# Altium schematic sheet SCH_BSPD_Main.SchDoc
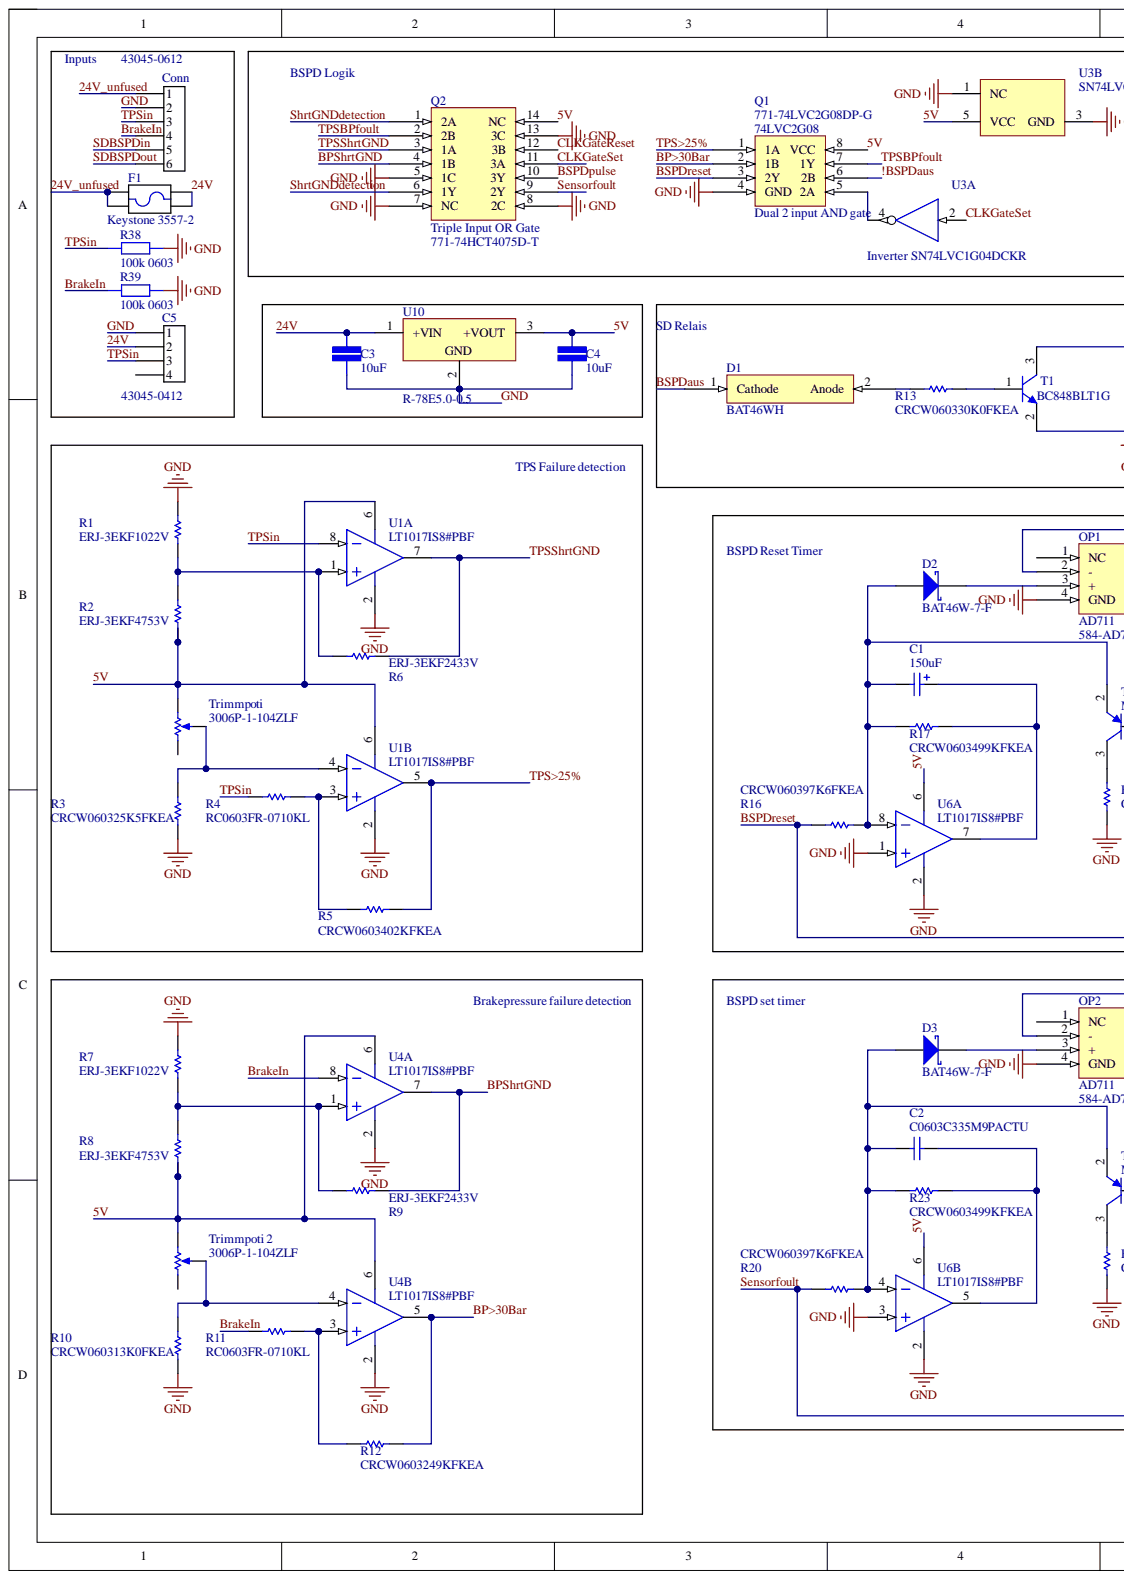
[diagram p0: SCH_BSPD_Main.SchDoc, left]
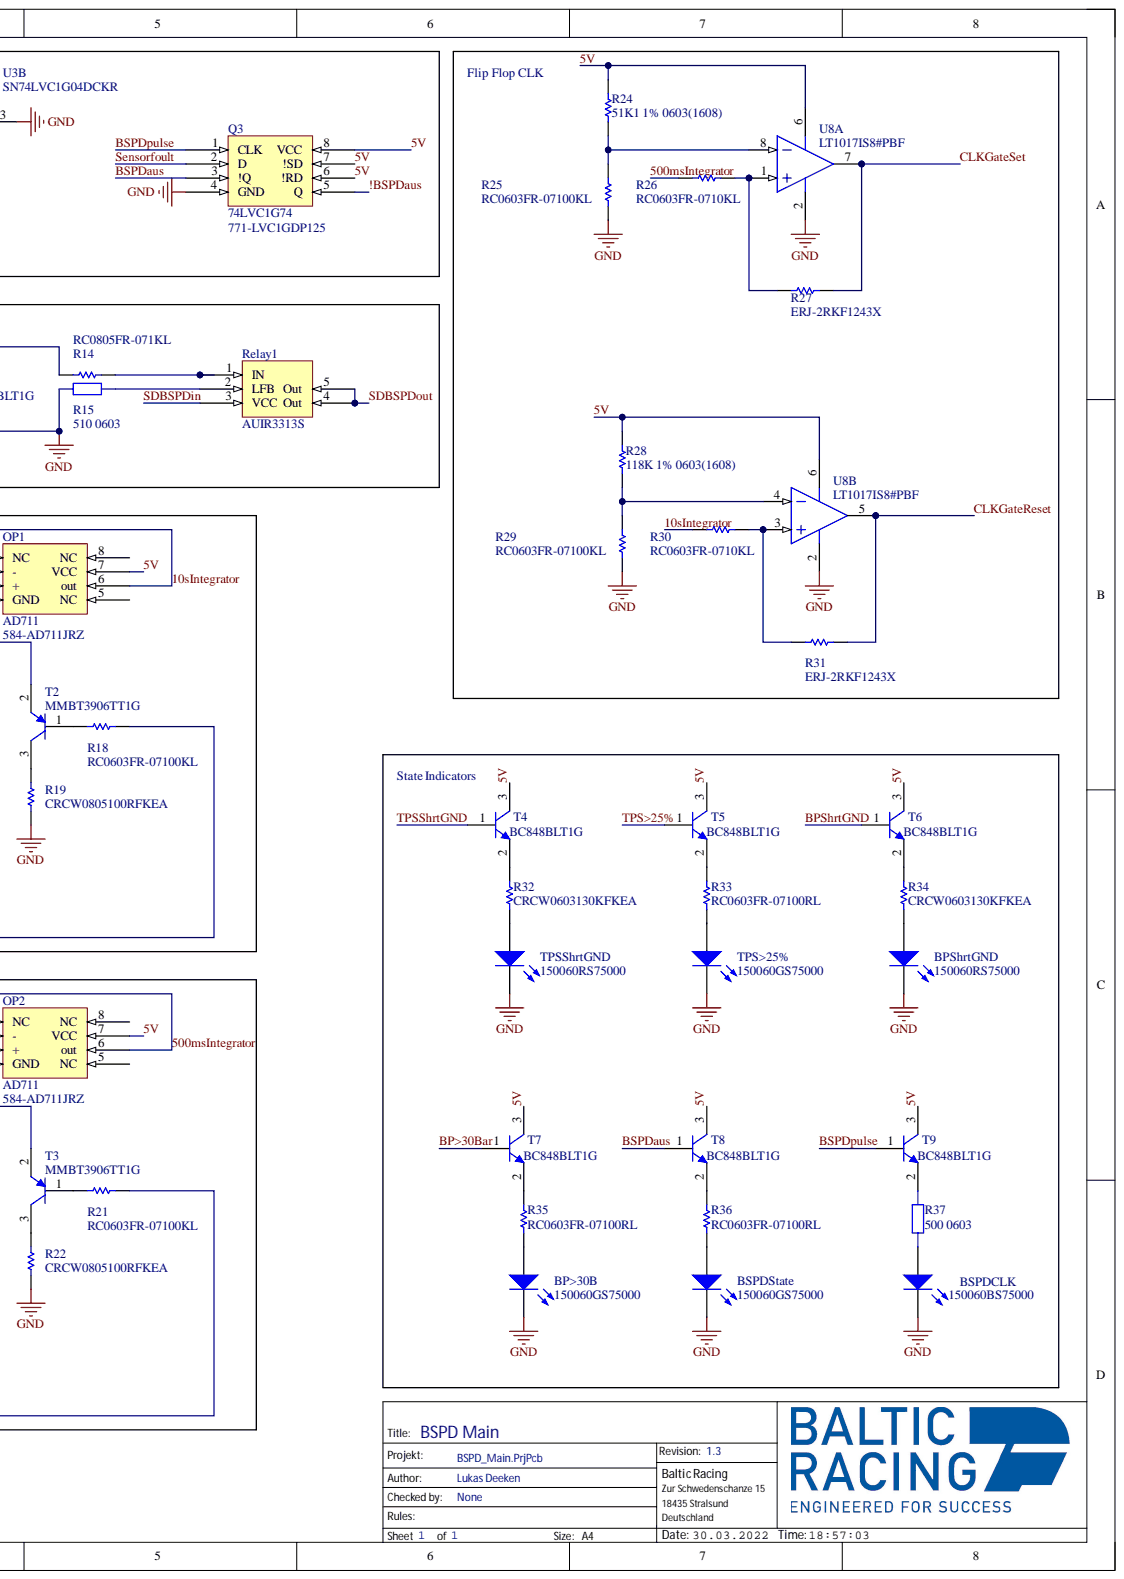
[diagram p1: SCH_BSPD_Main.SchDoc, right]

## Parts
BP>30B  150060GS75000  [0603_A]
BPShrtGND  150060RS75000  [0603_A]
BSPDCLK  150060BS75000  [0603_A]
BSPDState  150060GS75000  [0603_A]
C1  value 150uF  [WCAP-PSLC_10x12.4]  (hidden comment: 875075561006)
C2  C0603C335M9PACTU  [CAPC1608X90X35NL15T15]
C3  value 10uF  [CAP 0805/2012]  (hidden comment: SMD Capacitor)
C4  value 10uF  [CAP 0805/2012]  (hidden comment: SMD Capacitor)
C5  43045-0412  [43045-0412]
Conn  43045-0612  [43045-0612]
D1  BAT46WH  [DIOM2715X18N]
D2  BAT46W-7-F  [DIOD-SOD-123-2_V]
D3  BAT46W-7-F  [DIOD-SOD-123-2_V]
F1  Keystone 3557-2  [Keystone 3557-2]
OP1  AD711  Supplier Part Number 1: 584-AD711JRZ  [SOIC8; SOIC127P600X175-8N]
OP2  AD711  Supplier Part Number 1: 584-AD711JRZ  [SOIC8; SOIC127P600X175-8N]
Q1  Dual 2 input AND gate  Supplier Part Number 1: 771-74LVC2G08DP-G  [TSSOP8; TSOP65P400X110-8N]
Q2  Triple Input OR Gate  Supplier Part Number 1: 771-74HCT4075D-T  [SOIC14; SOIC127P600X175-14N]
Q3  74LVC1G74  Supplier Part Number 1: 771-LVC1GDP125  [TSSOP8; TSOP65P400X110-8N]
R1  ERJ-3EKF1022V  [RESC1608X55X30LL15T15]
R2  ERJ-3EKF4753V  [RESC1608X55X30LL15T15]
R3  CRCW060325K5FKEA  [RESC1609X50X30ML10T20]
R4  RC0603FR-0710KL  [RESC1608X55X25ML10T15]
R5  CRCW0603402KFKEA  [RESC1609X50X30ML10T20]
R6  ERJ-3EKF2433V  [RESC1608X55X30ML15T15]
R7  ERJ-3EKF1022V  [RESC1608X55X30LL15T15]
R8  ERJ-3EKF4753V  [RESC1608X55X30LL15T15]
R9  ERJ-3EKF2433V  [RESC1608X55X30ML15T15]
R10  CRCW060313K0FKEA  [RESC1609X50X30NL10T20]
R11  RC0603FR-0710KL  [RESC1608X55X25ML10T15]
R12  CRCW0603249KFKEA  [RESC1609X50X30NL10T20]
R13  CRCW060330K0FKEA  [RESC1609X50X30LL10T20]
R14  RC0805FR-071KL  [RESC2013X60X35ML10T20]
R15  value 510 0603  [STACKPOLE CSR0603]  (hidden comment: SMD Resistor)
R16  CRCW060397K6FKEA  [RESC1609X50X30LL10T20]
R17  CRCW0603499KFKEA  [RESC1609X50X30NL10T20]
R18  RC0603FR-07100KL  [RESC1608X55X25ML10T15]
R19  CRCW0805100RFKEA  [RESC2013X50X30LL20T20]
R20  CRCW060397K6FKEA  [RESC1609X50X30LL10T20]
R21  RC0603FR-07100KL  [RESC1608X55X25ML10T15]
R22  CRCW0805100RFKEA  [RESC2013X50X30LL20T20]
R23  CRCW0603499KFKEA  [RESC1609X50X30NL10T20]
R24  51K1 1% 0603(1608)  [RESC0603(1608)_L]
R25  RC0603FR-07100KL  [RESC1608X55X25ML10T15]
R26  RC0603FR-0710KL  [RESC1608X55X25ML10T15]
R27  ERJ-2RKF1243X  [RESC1005X40X25NL05T05]
R28  118K 1% 0603(1608)  [RESC0603(1608)_M]
R29  RC0603FR-07100KL  [RESC1608X55X25ML10T15]
R30  RC0603FR-0710KL  [RESC1608X55X25ML10T15]
R31  ERJ-2RKF1243X  [RESC1005X40X25NL05T05]
R32  CRCW0603130KFKEA  [RESC1609X50X30NL10T20]
R33  RC0603FR-07100RL  [RESC1608X55X25ML10T15]
R34  CRCW0603130KFKEA  [RESC1609X50X30NL10T20]
R35  RC0603FR-07100RL  [RESC1608X55X25ML10T15]
R36  RC0603FR-07100RL  [RESC1608X55X25ML10T15]
R37  value 500 0603  [STACKPOLE CSR0603]  (hidden comment: SMD Resistor)
R38  value 100k 0603  [STACKPOLE CSR0603]  (hidden comment: SMD Resistor)
R39  value 100k 0603  [STACKPOLE CSR0603]  (hidden comment: SMD Resistor)
Relay1  AUIR3313S  [D2PAK5 ;DPAK228P1524X483-5N]
T1  BC848BLT1G  [ONSC-SOT-23-3-318-08_V]
T2  MMBT3906TT1G  [ONSC-SC-75-3-463-01_V]
T3  MMBT3906TT1G  [ONSC-SC-75-3-463-01_V]
T4  BC848BLT1G  [ONSC-SOT-23-3-318-08_V]
T5  BC848BLT1G  [ONSC-SOT-23-3-318-08_V]
T6  BC848BLT1G  [ONSC-SOT-23-3-318-08_V]
T7  BC848BLT1G  [ONSC-SOT-23-3-318-08_V]
T8  BC848BLT1G  [ONSC-SOT-23-3-318-08_V]
T9  BC848BLT1G  [ONSC-SOT-23-3-318-08_V]
TPS>25%  150060GS75000  [0603_A]
TPSShrtGND  150060RS75000  [0603_A]
Trimmpoti  3006P-1-104ZLF  [BOUR-3006P-3_V]
Trimmpoti_2  3006P-1-104ZLF  [BOUR-3006P-3_V]
U1  LT1017IS8#PBF  [LT-S8_N]  (parts A,B)
U3  Inverter SN74LVC1G04DCKR  [DCK0005A_N]  (parts A,B)
U4  LT1017IS8#PBF  [LT-S8_N]  (parts A,B)
U6  LT1017IS8#PBF  [LT-S8_N]  (parts A,B)
U8  LT1017IS8#PBF  [LT-S8_N]  (parts A,B)
U10  R-78E5.0-0.5  [REPO-R-78E-0.5-3_V]

## Nets
5V: C4.2 OP1.7(VCC) OP2.7(VCC) Q1.8(VCC) Q2.14(NC) Q3.6(!RD) Q3.7(!SD) Q3.8(VCC) R2.1 R8.1 R24.2 R28.2 T4.3 T5.3 T6.3 T7.3 T8.3 T9.3 Trimmpoti.1 Trimmpoti_2.1 U1.6 U3.5(VCC) U4.6 U6.6 U8.6 U10.3
10sIntegrator: OP1.2(-) OP1.6(out) R30.1
24V: C3.2 C5.2 F1.O1 F1.O2 U10.1
24V_unfused: Conn.1 F1.I1 F1.I2
500msIntegrator: OP2.2(-) OP2.6(out) R26.1
!BSPDaus: Q1.6(2B) Q3.5(Q)
BP>30Bar: Q1.2(1B) R12.2 T7.1 U4.5
BPShrtGND: Q2.4(1B) R9.2 T6.1 U4.7
BrakeIn: Conn.4 R11.1 R39.1 U4.8
BSPDaus: D1.1 Q3.3(!Q) T8.1
BSPDpulse: Q2.10(3Y) Q3.1(CLK) T9.1
BSPDreset: Q1.3(2Y) R16.1 R18.1
CLKGateReset: Q2.12(3B) R31.2 U8.5
CLKGateSet: Q2.11(3A) R27.2 U3.2 U8.7
GND: BP>30B.1 BPShrtGND.1 BSPDCLK.1 BSPDState.1 C3.1 C4.1 C5.1 Conn.2 OP1.4(GND) OP2.4(GND) Q1.4(GND) Q2.5(1C) Q2.7(NC) Q2.8(2C) Q2.13(3C) Q3.4(GND) R1.2 R3.1 R7.2 R10.1 R15.1 R19.2 R22.2 R25.1 R29.1 R38.2 R39.2 T1.2 TPS>25%.1 TPSShrtGND.1 U1.2 U3.1(NC) U3.3(GND) U4.2 U6.1 U6.2 U6.3 U8.2 U10.2
SDBSPDin: Conn.5 Relay1.3(VCC)
SDBSPDout: Conn.6 Relay1.4(Out) Relay1.5(Out)
Sensorfoult: Q2.9(2Y) Q3.2(D) R20.1 R21.1
ShrtGNDdetection: Q2.1(2A) Q2.6(1Y)
TPS>25%: Q1.1(1A) R5.2 T5.1 U1.5
TPSBPfoult: Q1.7(1Y) Q2.2(2B)
TPSin: C5.3 Conn.3 R4.1 R38.1 U1.8
TPSShrtGND: Q2.3(1A) R6.2 T4.1 U1.7
NetBP>30B_2: BP>30B.2 R35.2
NetBPShrtGND_2: BPShrtGND.2 R34.1
NetBSPDCLK_2: BSPDCLK.2 R37.1
NetBSPDState_2: BSPDState.2 R36.2
NetC1_1: C1.1 R17.2 U6.7
NetC1_2: C1.2 D2.1 R16.2 R17.1 T2.2 U6.8
NetC2_1: C2.1 D3.1 R20.2 R23.1 T3.2 U6.4
NetC2_2: C2.2 R23.2 U6.5
NetD1_2: D1.2 R13.1
NetD2_2: D2.2 OP1.3(+)
NetD3_2: D3.2 OP2.3(+)
NetQ1_5: Q1.5(2A) U3.4
NetR1_1: R1.1 R2.2 R6.1 U1.1
NetR3_2: R3.2 Trimmpoti.2 U1.4
NetR4_2: R4.2 R5.1 U1.3
NetR7_1: R7.1 R8.2 R9.1 U4.1
NetR10_2: R10.2 Trimmpoti_2.2 U4.4
NetR11_2: R11.2 R12.1 U4.3
NetR13_2: R13.2 T1.1
NetR14_1: R14.1 T1.3
NetR14_2: R14.2 Relay1.1(IN)
NetR15_2: R15.2 Relay1.2(LFB)
NetR18_2: R18.2 T2.1
NetR19_1: R19.1 T2.3
NetR21_2: R21.2 T3.1
NetR22_1: R22.1 T3.3
NetR24_1: R24.1 R25.2 U8.8
NetR26_2: R26.2 R27.1 U8.1
NetR28_1: R28.1 R29.2 U8.4
NetR30_2: R30.2 R31.1 U8.3
NetR32_1: R32.1 TPSShrtGND.2
NetR32_2: R32.2 T4.2
NetR33_1: R33.1 T5.2
NetR33_2: R33.2 TPS>25%.2
NetR34_2: R34.2 T6.2
NetR35_1: R35.1 T7.2
NetR36_1: R36.1 T8.2
NetR37_2: R37.2 T9.2

Not connected: C5 4; OP1 1(NC) 5(NC) 8(NC); OP2 1(NC) 5(NC) 8(NC); Trimmpoti 3; Trimmpoti_2 3

## Library data of the parts
BP>30B BSPDState TPS>25% = CMP-1426-00002-1: SMD mono-color Chip LED, WL-SMCW, Green; Manufacturer: Wuerth Elektronik; Color: Green; Component Kind: Standard; ComponentLink1Description: Manufacturer URL; ComponentLink1URL: http://www.we-online.com; ComponentLink2Description: Datasheet; ComponentLink2URL: http://katalog.we-online.com/led/datasheet/150060GS75000.pdf; Library Name: Altium Content Vault; PackageReference: 0603_A; PartNumber: 150060GS75000
BPShrtGND TPSShrtGND = CMP-1426-00001-1: SMD mono-color Chip LED, WL-SMCW, Red; Manufacturer: Wuerth Elektronik; Color: Red; Component Kind: Standard; ComponentLink1Description: Manufacturer URL; ComponentLink1URL: http://www.we-online.com; ComponentLink2Description: Datasheet; ComponentLink2URL: http://katalog.we-online.com/led/datasheet/150060RS75000.pdf; Library Name: Altium Content Vault; PackageReference: 0603_A; PartNumber: 150060RS75000
BSPDCLK = CMP-1426-00003-1: SMD mono-color Chip LED, WL-SMCW, Blue; Manufacturer: Wuerth Elektronik; Color: Blue; Component Kind: Standard; ComponentLink1Description: Manufacturer URL; ComponentLink1URL: http://www.we-online.com; ComponentLink2Description: Datasheet; ComponentLink2URL: http://katalog.we-online.com/led/datasheet/150060BS75000.pdf; Library Name: Altium Content Vault; PackageReference: 0603_A; PartNumber: 150060BS75000
C1 = 875075561006: WCAP-PSLC Aluminum Polymer Capacitors, size Ф10x12.4mm, 25V DC, 150uF; Rated Voltage: 25V DC; Component Kind: Standard; ComponentLink1Description: Manufacturer; ComponentLink1URL: http://www.we-online.de; ComponentLink2Description: Datasheet; ComponentLink2URL: http://katalog.we-online.de/pbs/datasheet/875075561006.pdf; Library Name: ALTIUM-WCAP-PSLC-rev17b.IntLib; Life Cycle: 2000h; Operating Temperature: -55 °C up to +105 °C; Part Number: 875075561006; Published Date: June 2017; Size: Ф10x12.4mm; Publisher: Wurth Elektronik
C2 = CMP-2006-02261-1: Manufacturer: Kemet; Component Kind: Standard; Library Name: Altium Content Vault; Part Number: C0603C335M9PACTU
C3 = SMD Capacitor: Capacitor with multiple Footprints; Voltage: 25V; Component Kind: Standard; Library Name: Standardbauteile.IntLib
C4 = SMD Capacitor: Capacitor with multiple Footprints; Voltage: 6.3V; Component Kind: Standard; Library Name: Standardbauteile.IntLib
C5 = MicroFit3.0 4-Pin: Molex Micro-Fit 3.0 4-Pin  600V  8.5A; Component Kind: Standard; Library Name: Standardbauteile.IntLib
Conn = MicroFit3.0 6-Pin: Molex Micro-Fit 3.0 6-Pin  600V  8.5A; Component Kind: Standard; Library Name: Standardbauteile.IntLib
D2 D3 = CMP-0303-00004-1: Surface Mount Schottky Barrier Diode, 100 V, -55 to 125 degC, 2-Pin SOD123, RoHS, Tape and Reel; Manufacturer: Diodes Inc; CT@1 V: 12 pF Typ.; IR@1.5 V: 0.3 uA Max.; V(BR)R: 100 V Min.; VF@10 mA: 0.45 V Max.; Component Kind: Standard; ComponentLink1Description: Manufacturer URL; ComponentLink1URL: http://www.diodes.com/; ComponentLink2Description: Datasheet; ComponentLink2URL: http://diodes.com/datasheets/ds30044.pdf; ComponentLink3Description: Package Specification; ComponentLink3URL: http://diodes.com/datasheets/ds30044.pdf; DatasheetVersion: Rev. 16-2; Library Name: Altium Content Vault; Mounting Technology: Surface Mount; Operating Temperature: -55 to 125 degC; PackageDescription: 2-Pin SMD, Body 2.7 x 1.55 mm, Height 1.45 mm; Packing: Tape and Reel; PartNumber: BAT46W-7-F; RoHS: TRUE
F1 = Keystone 3557-2: Keystone 3557-2  Fuse Holder; Component Kind: Standard; ComponentLink1Description: Octopart; ComponentLink1URL: https://octopart.com/3557-2-keystone-850400?r=sp; Library Name: libNico.IntLib
Q1 = 74LVC2G08-Q100: Component Kind: Standard; Supplier 1: Mouser; Library Name: Integrated_LibraryLukas.IntLib
Q2 = 74HCT4075D,653: Component Kind: Standard; Supplier 1: Mouser; Library Name: Integrated_LibraryLukas.IntLib
R1 R7 = CMP-2000-00185-1: Manufacturer: Panasonic; Component Kind: Standard; Library Name: Altium Content Vault; Part Number: ERJ-3EKF1022V
R2 R8 = CMP-2000-00528-1: Manufacturer: Panasonic; Component Kind: Standard; Library Name: Altium Content Vault; Part Number: ERJ-3EKF4753V
R3 = CMP-2000-03129-1: Manufacturer: Vishay Dale; Component Kind: Standard; Library Name: Altium Content Vault; Part Number: CRCW060325K5FKEA
R4 R11 R26 R30 = CMP-2100-03664-1: Chip Resistor, 10 KOhm, +/- 1%, 01 W, -55 to 155 degC, 0603 (1608 Metric), RoHS, Tape and Reel; Manufacturer: Yageo; Power Rating: 100mW; Resistance: 10KOhm; Temperature Coefficient: 100ppm/degreesC; Voltage Rating: 75V; Voltage Rating (DC): 50V; Case Code (Imperial): 0603; Case Code (Metric): 1608; Case/Package: 0603; Component Kind: Standard; Composition: ThickFilm; Datasheet URL: http://www.yageo.com/NewPortal/yageodocoutput?fileName=/pdf/R-Chip/PYu-RC_Group_51_RoHS_L_5.pdf; Datasheet Version: Rev. 5, 10/2016; Depth: 0.8mm; Height: 0.45mm; Lead Free: LeadFree; Lead Pitch: 0.3mm; Length: 1.6mm; Library Name: Altium Content Vault; Manufacturer URL: http://www.yageo.com/; Max Operating Temperature: 155degC; Military Standard: Not; Min Operating Temperature: -55degC; Number of Pins: 2; Package Description: 2-Pin Surface Mount Device, Body 1.6 x 0.8 mm; Package Reference: 0603; Packaging: TapeandReel; REACH SVHC: NoSVHC; RoHSCompliant: true; Termination: SMD/SMT; Tolerance: 1%; Weight: 7.1E-05oz; Width: 0.8mm
R5 = CMP-2000-03334-1: Manufacturer: Vishay Dale; Component Kind: Standard; Library Name: Altium Content Vault; Part Number: CRCW0603402KFKEA
R6 R9 = CMP-2000-00367-1: Manufacturer: Panasonic; Component Kind: Standard; Library Name: Altium Content Vault; Part Number: ERJ-3EKF2433V
R10 = CMP-2000-03895-1: Manufacturer: Vishay Dale; Component Kind: Standard; Library Name: Altium Content Vault; Part Number: CRCW060313K0FKEA
R12 = CMP-2000-03115-1: Manufacturer: Vishay Dale; Component Kind: Standard; Library Name: Altium Content Vault; Part Number: CRCW0603249KFKEA
R13 = CMP-2000-03223-1: Manufacturer: Vishay Dale; Component Kind: Standard; Library Name: Altium Content Vault; Part Number: CRCW060330K0FKEA
R14 = CMP-2100-03671-1: Chip Resistor, 1 KOhm, +/- 1%, 125 mW, -55 to 155 degC, 0805 (2012 Metric), RoHS, Tape and Reel; Manufacturer: Yageo; Max Power Dissipation: 125mW; Resistance: 1KOhm; Temperature Coefficient: 100ppm/degreesC; Voltage Rating: 150V; Case Code (Imperial): 0805; Case Code (Metric): 2012; Case/Package: 0805; Component Kind: Standard; Composition: ThickFilm; Datasheet URL: http://www.yageo.com/NewPortal/yageodocoutput?fileName=/pdf/R-Chip/PYu-RC_Group_51_RoHS_L_5.pdf; Datasheet Version: Rev. 5, 10/2016; Depth: 1.25mm; Height: 0.024inch; Lead Free: LeadFree; Length: 0.079inch; Library Name: Altium Content Vault; Manufacturer URL: http://www.yageo.com/; Max Operating Temperature: 155degC; Military Standard: Not; Min Operating Temperature: -55degC; Number of Pins: 2; Number of Terminations: 2; Package Description: 2-Pin Surface Mounted Device, Body 2 x 1.25 mm; Package Quantity: 1; Package Reference: 0805; Packaging: TapeandReel; Power Rating: 125mW; REACH SVHC: Unknown; RoHSCompliant: true; Termination: SMD/SMT; Tolerance: 1%; Voltage Rating (DC): 150V; Width: 0.049inch
R15 R37-R39 = SMD Resistor: Resistor with multiple footprints; Component Kind: Standard; Library Name: Standardbauteile.IntLib
R16 R20 = CMP-2000-03650-1: Manufacturer: Vishay Dale; Component Kind: Standard; Library Name: Altium Content Vault; Part Number: CRCW060397K6FKEA
R17 R23 = CMP-2000-03389-1: Manufacturer: Vishay Dale; Component Kind: Standard; Library Name: Altium Content Vault; Part Number: CRCW0603499KFKEA
R18 R21 R25 R29 = CMP-2100-03662-1: Chip Resistor, 100 KOhm, +/- 1%, 0.1 W, -55 to 155 degC, 0603 (1608 Metric), RoHS, Tape and Reel; Manufacturer: Yageo; Power Rating: 100mW; Temperature Coefficient: 100ppm/degreesC; Voltage Rating: 50V; Case Code (Imperial): 0603; Case Code (Metric): 1608; Case/Package: 0603; Component Kind: Standard; Composition: ThickFilm; Datasheet URL: http://www.yageo.com/NewPortal/yageodocoutput?fileName=/pdf/R-Chip/PYu-RC_Group_51_RoHS_L_5.pdf; Datasheet Version: Rev. 5, 10/2016; Depth: 0.8mm; Height: 0.45mm; Lead Free: LeadFree; Length: 1.6mm; Library Name: Altium Content Vault; Manufacturer URL: http://www.yageo.com/; Max Operating Temperature: 155degC; Military Standard: Not; Min Operating Temperature: -55degC; Number of Pins: 2; Package Description: 2-Pin Surface Mount Device, Body 1.6 x 0.8 mm; Package Reference: 0603; Packaging: TapeandReel; REACH SVHC: NoSVHC; Resistance: 100KOhm; RoHSCompliant: true; Termination: SMD/SMT; Tolerance: 1%; Voltage Rating (DC): 50V; Width: 0.8mm
R19 R22 = CMP-2001-04901-1: Manufacturer: Vishay Dale; Component Kind: Standard; Library Name: Altium Content Vault; Part Number: CRCW0805100RFKEA
R24 = CMP-1012-00704-1: 51K1 0.1W 1% 0603 (1608 Metric)  SMD; Case-EIA: 0603; Case-Metric: 1608; Value: 51100.00 Ohm; Component Kind: Standard; Library Name: Altium Content Vault; Power: 0.1 W; Technology: SMT; Tolerance: 1 %
R27 R31 = CMP-2002-00654-1: Manufacturer: Panasonic; Component Kind: Standard; Library Name: Altium Content Vault; Part Number: ERJ-2RKF1243X
R28 = CMP-1012-00743-1: 118K 0.1W 1% 0603 (1608 Metric)  SMD; Case-EIA: 0603; Case-Metric: 1608; Value: 118000.00 Ohm; Component Kind: Standard; Library Name: Altium Content Vault; Power: 0.1 W; Technology: SMT; Tolerance: 1 %
R32 R34 = CMP-2000-03887-1: Manufacturer: Vishay Dale; Component Kind: Standard; Library Name: Altium Content Vault; Part Number: CRCW0603130KFKEA
R33 R35 R36 = CMP-2100-03663-1: Chip Resistor, 100 Ohm, +/- 1%, 0.1 W, -55 to 155 degC, 0603 (1608 Metric), RoHS, Tape and Reel; Manufacturer: Yageo; Power Rating: 100mW; Resistance: 100Ohm; Temperature Coefficient: 100ppm/degreesC; Voltage Rating: 50V; Case Code (Imperial): 0603; Case Code (Metric): 1608; Case/Package: 0603; Component Kind: Standard; Composition: ThickFilm; Datasheet URL: http://www.yageo.com/NewPortal/yageodocoutput?fileName=/pdf/R-Chip/PYu-RC_Group_51_RoHS_L_5.pdf; Datasheet Version: Rev. 5, 10/2016; Depth: 0.8mm; Height: 0.45mm; Lead Free: LeadFree; Length: 1.6mm; Library Name: Altium Content Vault; Manufacturer URL: http://www.yageo.com/; Max Operating Temperature: 155degC; Military Standard: Not; Min Operating Temperature: -55degC; Number of Pins: 2; Package Description: 2-Pin Surface Mount Device, Body 1.6 x 0.8 mm; Package Reference: 0603; Packaging: TapeandReel; REACH SVHC: Unknown; RoHSCompliant: true; Termination: SMD/SMT; Tolerance: 1%; Voltage Rating (DC): 50V; Width: 0.8mm
T1 T4-T9 = CMP-1048-00679-1: General Purpose Transistor, NPN Silicon, 3-Pin SOT-23, Pb-Free, Tape and Reel; Manufacturer: On Semiconductor; fT Min (MHz): 100; IC Continuous (A): 0.1; Component Kind: Standard; ComponentLink1Description: Manufacturer URL; ComponentLink1URL: http://www.onsemi.com/; ComponentLink2Description: Datasheet; ComponentLink2URL: http://www.onsemi.cn/pub_link/Collateral/BC846ALT1-D.PDF; ComponentLink3Description: Package Specification; ComponentLink3URL: http://www.onsemi.cn/pub_link/Collateral/318-08.PDF; DatasheetVersion: Rev. 11; Library Name: Altium Content Vault; Mounting Technology: Surface Mount; PackageDescription: 3-Pin Small Outline Transistor, Body 2.92 x 1.3 mm, Pitch 0.95 mm; PackageReference: SOT-23-3-318-08; PackageVersion: Rev. AP, 11/2009; Packing: Tape and Reel; PartNumber: BC848BLT1G; Polarity: NPN; RoHS: TRUE
T2 T3 = CMP-1048-00413-1: General Purpose Transistor, PNP Silicon, 3-Pin SC-75, Pb-Free, Tape and Reel; Manufacturer: On Semiconductor; fT Min (MHz): 250; IC Continuous (A): -0.2; Component Kind: Standard; ComponentLink1Description: Manufacturer URL; ComponentLink1URL: http://www.onsemi.com/; ComponentLink2Description: Datasheet; ComponentLink2URL: http://www.onsemi.cn/pub_link/Collateral/MMBT3906TT1-D.PDF; ComponentLink3Description: Package Specification; ComponentLink3URL: http://www.onsemi.com/pub_link/Collateral/463-01.PDF; DatasheetVersion: Rev. 1; Library Name: Altium Content Vault; Mounting Technology: Surface Mount; PackageDescription: 3-Pin Small Outline Transistor, Body 1.6 x 0.8 mm, Pitch 0.5 mm; PackageReference: SC-75-3-463-01; PackageVersion: Rev. F, 07/2005; Packing: Tape and Reel; PartNumber: MMBT3906TT1G; Polarity: PNP; RoHS: TRUE
Trimmpoti Trimmpoti_2 = CMP-0975-00010-1: Trimming Potentiometer, 100K Ohm +/- 10%, -55 to 125 degC, 3-Pin 19.05 x 4.83 x 6.6 mm THD, RoHS; Manufacturer: Bourns; Number of Turns: 15; Resistance (kOhm): 100; Resistance Tolerance: +/- 10%; Rotational Life: 200 Cycles; Component Kind: Standard; ComponentLink1Description: Manufacturer URL; ComponentLink1URL: http://www.bourns.com/; ComponentLink2Description: Datasheet; ComponentLink2URL: http://www.bourns.com/data/global/pdfs/3006.pdf; ComponentLink3Description: Package Specification; ComponentLink3URL: http://www.bourns.com/data/global/pdfs/3006.pdf; DatasheetVersion: Apr-14; Library Name: Altium Content Vault; Mounting Technology: Through Hole; PackageDescription: 3-Pin THD, Body 19.05 x 4.83 mm, Height 6.6 mm; PackageReference: 3006P-3; PackageVersion: Apr-14; PartNumber: 3006P-1-104ZLF; RoHS: TRUE; Temperature Range: -55 to 125 degC
U1 U4 U6 U8 = CMP-0360-00547-2: Micropower Comparator, 2 Channels, 1.2 to 40 V, -40 to +85 degC, 8-pin SOP (S8); Generic Part Number: LT1017; Manufacturer: Linear Technology; Channels (#): 2; Prop Delay (us): 22; Supply Current (uA) Guaranteed: 60; Supply Current (uA) Typical: 30; Vos (mV) Guaranteed: 1; Vos (mV) Typical: 0.4; Vs Max (V): 40; Vs Min (V): 1.2; Component Kind: Standard; ComponentLink1Description: Manufacturer URL; ComponentLink1URL: http://www.linear.com/; ComponentLink2Description: Datasheet; ComponentLink2URL: http://cds.linear.com/docs/en/datasheet/10178ff.pdf; ComponentLink3Description: Package Specification; ComponentLink3URL: http://cds.linear.com/docs/en/packaging/05081610_G_SO8.pdf; DatasheetVersion: Rev F; Features: Comparator; Library Name: Altium Content Vault; Mounting Technology: Surface Mount; PackageDescription: 8-Pin Plastic Small Outline (Narrow .150 Inch), Body 4.9 x 3.9 mm, Pitch 1.27 mm; PackageReference: S8; PartNumber: LT1017IS8#PBF; RoHS: Y; Supply Voltage Range: 1.2 to 40 V
U3 = CMP-0859-00252-3: Single Inverter, DCK0005A, LARGE T&R; Manufacturer: Texas Instruments; 3-State Output: No; Bits(#): 1; F @ Nom Voltage(Max)(Mhz): 150; ICC @ Nom Voltage(Max)(mA): 0.01; Input Type: CMOS/TTL; Logic: Inverting; Output Drive (IOL/IOH)(Max)(mA): 32/-32; Output Type: CMOS; Rating: Catalog; Sub-Family: Inverting Buffer/Driver; Technology Family: LVC; tpd @ Nom Voltage(Max)(ns): 7.5,5.2,4.2,3.7; VCC(Max)(V): 5.5; VCC(Min)(V): 1.65; Voltage(Nom)(V): 1.8,2.5,3.3,5; Component Kind: Standard; DatasheetVersion: SCES214AD; Generic Part Number: SN74LVC1G04; Library Name: Altium Content Vault; Operating Temperature Range(C): -40 to 125,-40 to 85; PackageReference: DCK0005A; Schmitt Trigger: No
U10 = CMP-2000-06922-2: DC/DC-Converter, 5 V Single Output, 500 mA, -40 to 85 degC, 3-Pin THD, RoHS, Tube; Manufacturer: Recom Power; Current - Output (Max): 500mA; Efficiency: 92%; Number of Outputs: 1; Package Version: Rev. 0; VIN Max: 28V; VIN Min: 7V; VOUT: 5V; Component Kind: Standard; Datasheet URL: http://www.recom-power.com/pdf/Innoline/R-78Exx-0.5.pdf; Datasheet Version: 01/2017; Library Name: Altium Content Vault; Manufacturer URL: http://www.recom-power.com/us/americas/home.html; Mounting Technology: ThroughHole; Operating Temperature: -40°C~85°C; Package Description: 3-Pin Through Hole Device, Body 11.6 x 8.5 mm, Pitch 2.54 mm; Package Reference: SIP Module; Packaging: Tube
D1 = BAT46WH: Component Kind: Standard; Library Name: Integrated_LibraryLukas.IntLib
OP1 OP2 = AD711: Component Kind: Standard; Supplier 1: Mouser; Library Name: Integrated_LibraryLukas.IntLib
Q3 = 74LVC1G74: Component Kind: Standard; Supplier 1: Mouser; Library Name: Integrated_LibraryLukas.IntLib
Relay1 = AUIR3313S: Component Kind: Standard; Library Name: Integrated_LibraryLukas.IntLib
